# Altium schematic sheet Power_Supply.SchDoc
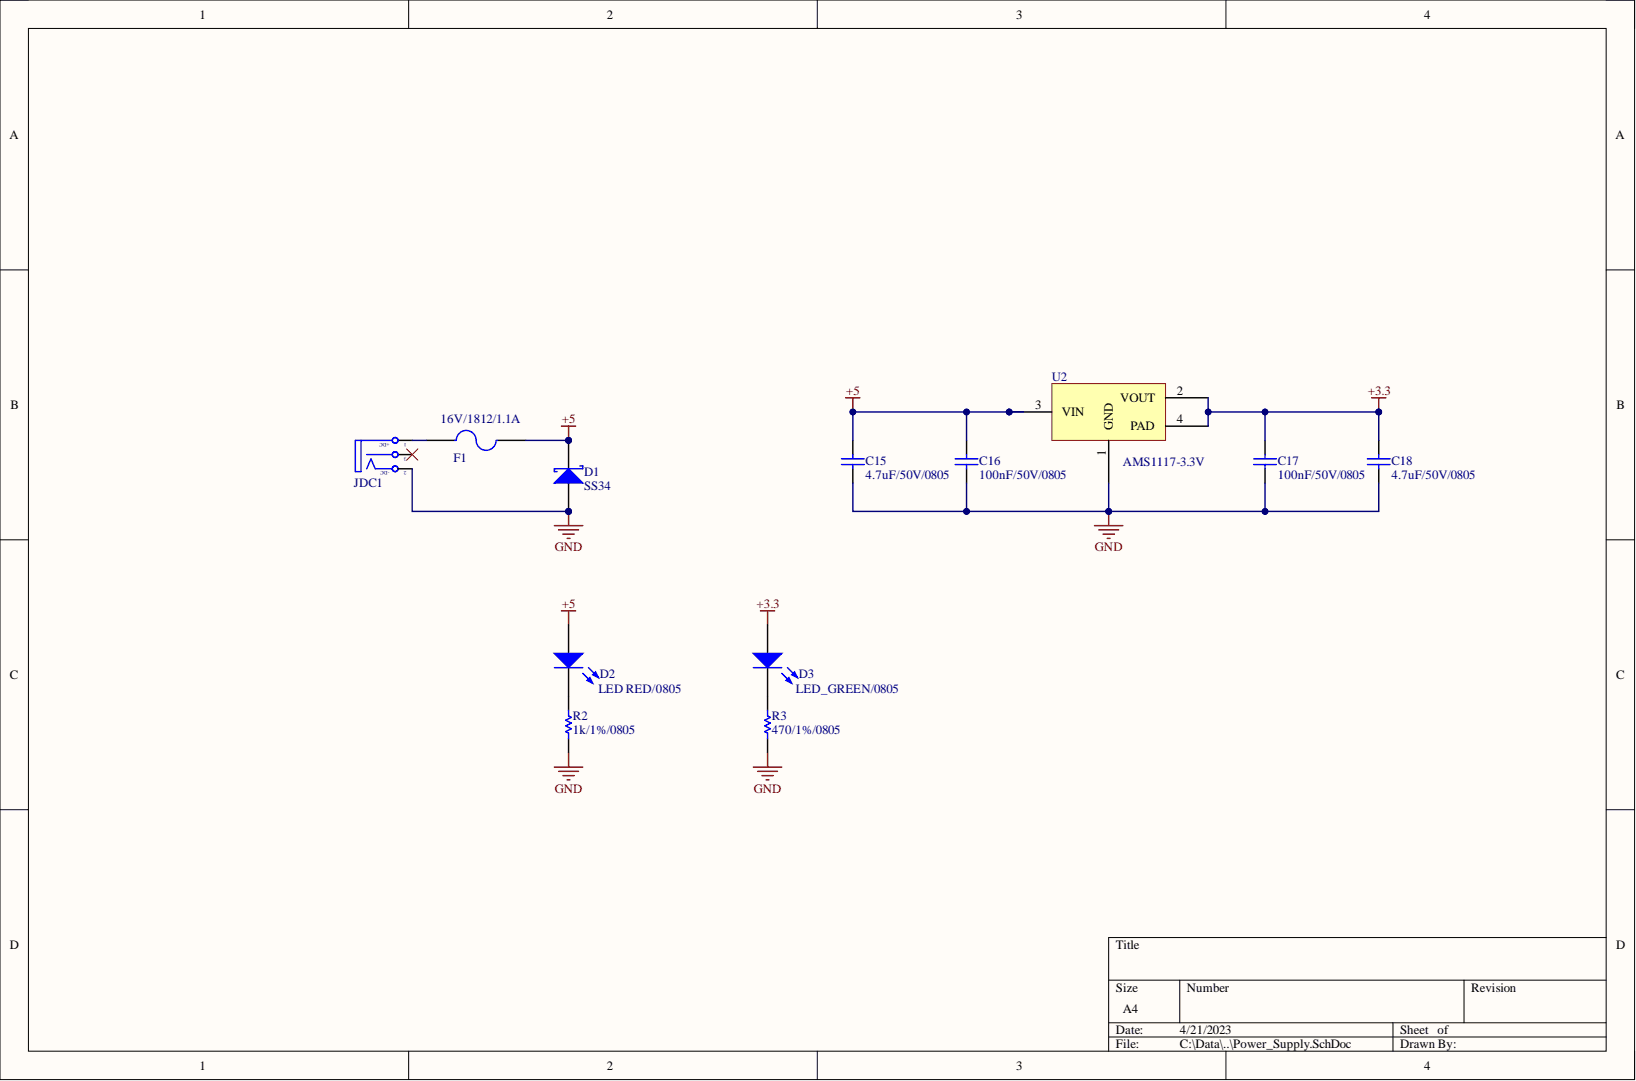
[diagram p0: Power_Supply.SchDoc]

## Parts
C15  value 4.7uF/50V/0805  [CAP-SM-0805]  (hidden comment: Cap Non-Pol SMD)
C16  value 100nF/50V/0805  [CAP-SM-0805]  (hidden comment: Cap Non-Pol SMD)
C17  value 100nF/50V/0805  [CAP-SM-0805]  (hidden comment: Cap Non-Pol SMD)
C18  value 4.7uF/50V/0805  [CAP-SM-0805]  (hidden comment: Cap Non-Pol SMD)
D1  D Schottky  [SS34]  (value not drawn)
D2  LED RED/0805  [LED_0805_Blue]
D3  LED_GREEN/0805  [LED_0805_Blue]
F1  Fuse  [FUSE SMD]  (value not drawn)
JDC1  Jack DC  [DC-IN]  (value not drawn)
R2  value 1k/1%/0805  [RES-SM-0805]  (hidden comment: RESISTOR SMD)
R3  value 470/1%/0805  [RES-SM-0805]  (hidden comment: RESISTOR SMD)
U2  AMS1117-3.3V  [SOT230P700X180-4N]

## Nets
+3.3: C17.1 C18.1 D3.1 U2.2(VOUT) U2.4(PAD)
+5: C15.1 C16.1 D1.K D2.1 F1.2 U2.3(VIN)
GND: C15.2 C16.2 C17.2 C18.2 D1.A JDC1.2 R2.1 R3.1 U2.1(GND)
NetD2_2: D2.2 R2.2
NetD3_2: D3.2 R3.2
NetF1_1: F1.1 JDC1.1

Not connected: JDC1 3

## Library data of the parts
C15-C18 = Cap Non-Pol SMD: Capacitor; Component Kind: Standard; Library Name: PIF_Library.IntLib
D1 = D Schottky: Schottky Diode; Code_JEDEC: DO-214-AA; Component Kind: Standard; LatestRevisionDate: 17-Jul-2002; LatestRevisionNote: Re-released for DXP Platform.; Library Name: PIF_Library.IntLib; PackageDescription: DO-214-AA/SMB; 2 C-Bend Leads; Body 5.3 x 3.6 mm, inc. leads (LxW); PackageReference: SMB; Published: 8-Jun-2000; Supplier 1: Arrow Electronics; Supplier 2: RSComponents; Supplier Part Number 1: SS34; Supplier Part Number 2: 8080433; Publisher: Altium Limited
D2 D3 = LED SMD: LED; Component Kind: Standard; Library Name: PIF_Library.IntLib
JDC1 = Jack DC: Jack DC input; Value: Jac DC; Component Kind: Standard; Library Name: PIF_Library.IntLib
R2 R3 = RESISTOR SMD: Resistor; Component Kind: Standard; Library Name: PIF_Library.IntLib
F1 = Fuse: Component Kind: Standard; Description: Fuse; Library Name: PIF_Library.IntLib
U2 = AMS1117-3.3V: Component Kind: Standard; Library Name: MiclsLib.IntLib
